# Altium schematic sheet pw_dist_v1.2_s1.SchDoc(pw_dist_v1.2_s1)
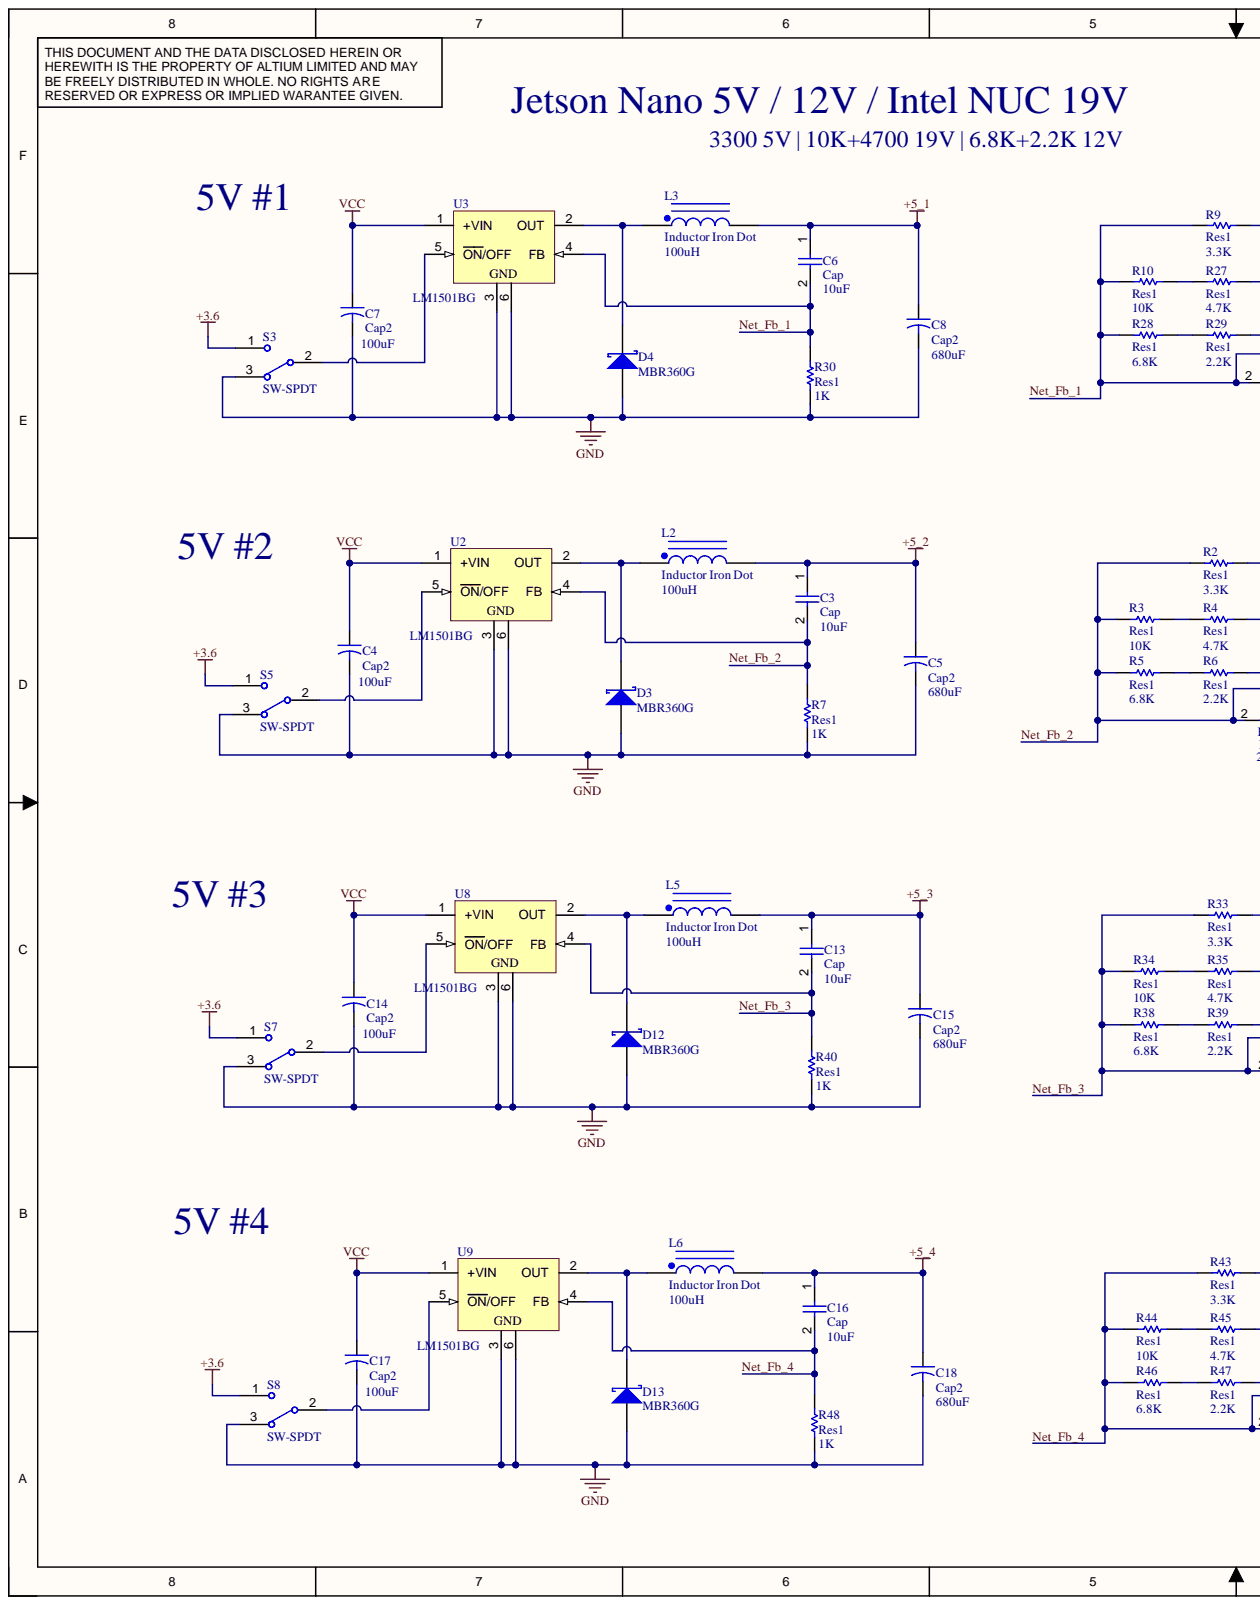
[diagram p0: pw_dist_v1.2_s1.SchDoc, left]
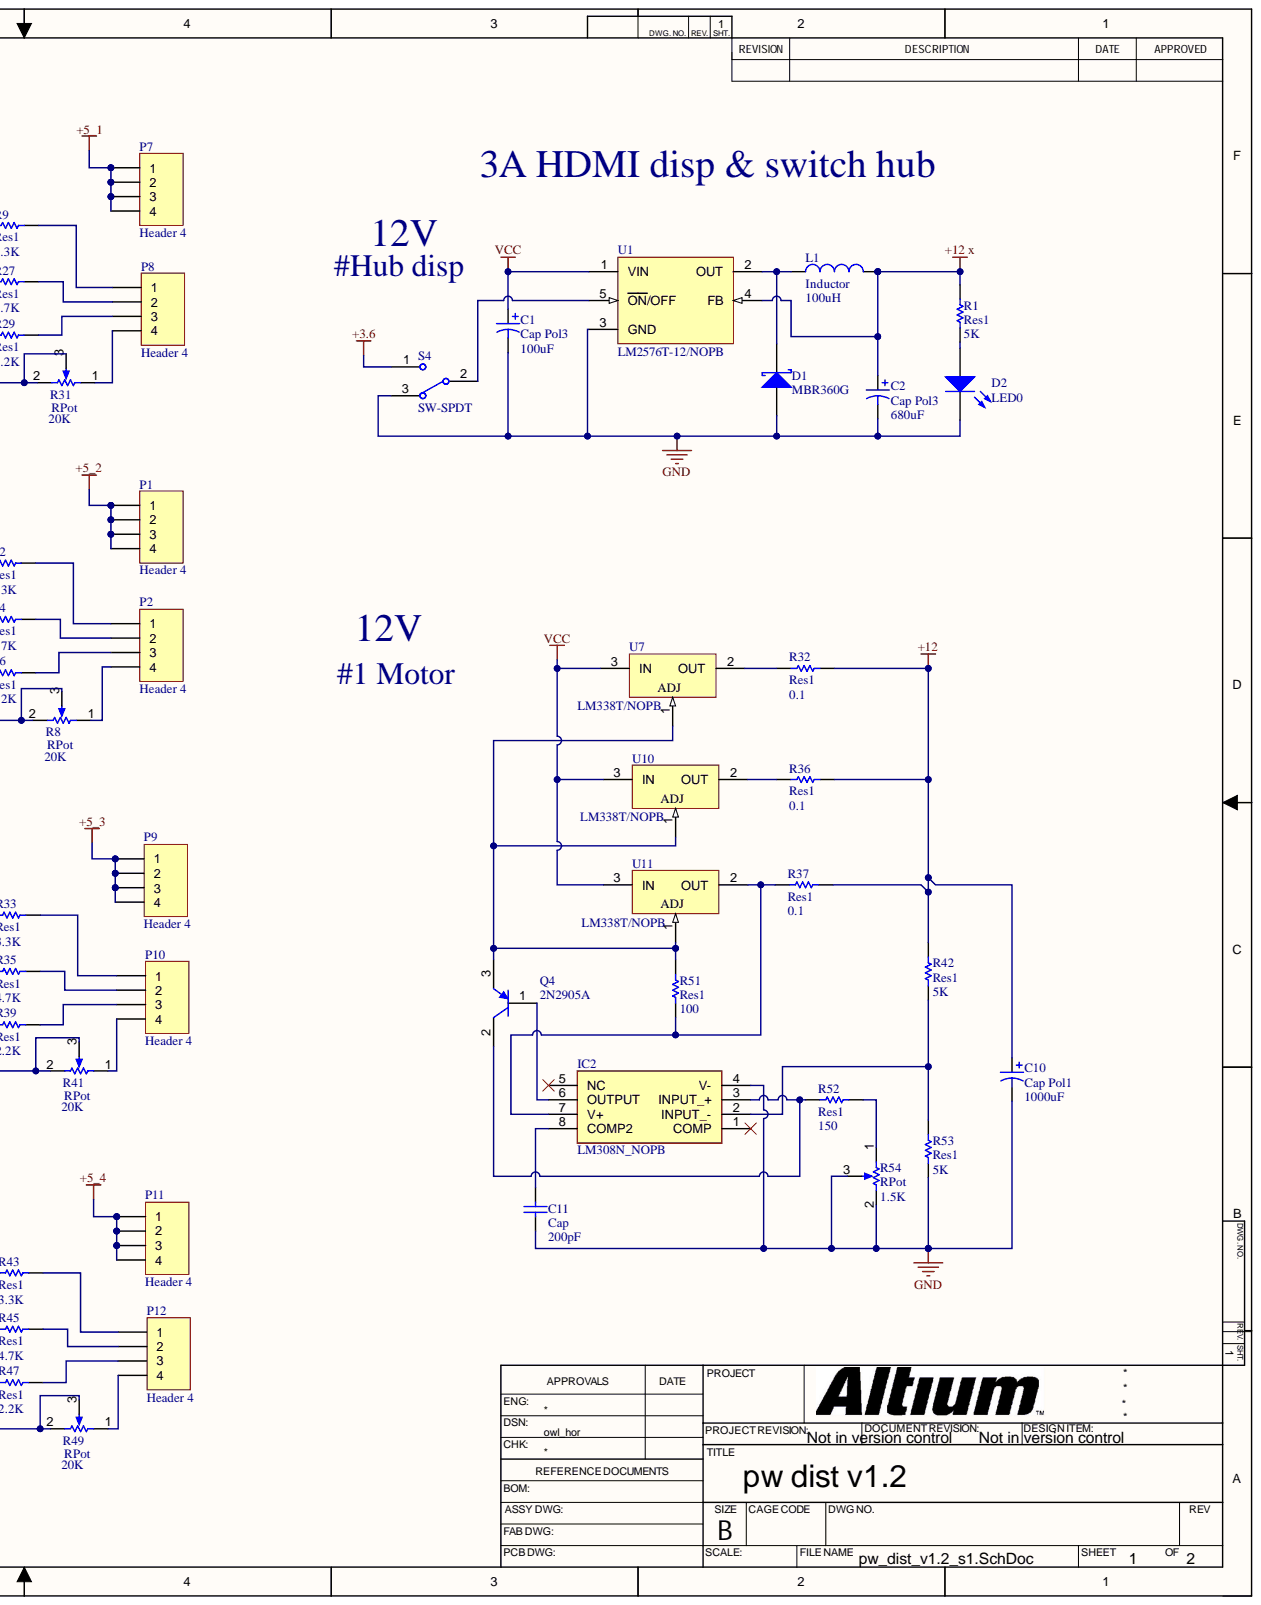
[diagram p1: pw_dist_v1.2_s1.SchDoc, right]

## Parts
C1  Cap Pol3  value 100uF  [CAPA_Q8_P3.5]
C2  Cap Pol3  value 680uF  [CAPA_Q10_P5.0]
C3  Cap  value 10uF  [Capa_Q5_p2.0]
C4  Cap2  value 100uF  [CAPA_Q8_P3.5]
C5  Cap2  value 680uF  [CAPA_Q10_P5.0]
C6  Cap  value 10uF  [Capa_Q5_p2.0]
C7  Cap2  value 100uF  [CAPA_Q8_P3.5]
C8  Cap2  value 680uF  [CAPA_Q10_P5.0]
C10  Cap Pol1  value 1000uF  [RB7.6-15]
C11  Cap  value 200pF  [RAD-0.1]
C13  Cap  value 10uF  [Capa_Q5_p2.0]
C14  Cap2  value 100uF  [CAPA_Q8_P3.5]
C15  Cap2  value 680uF  [CAPA_Q10_P5.0]
C16  Cap  value 10uF  [Capa_Q5_p2.0]
C17  Cap2  value 100uF  [CAPA_Q8_P3.5]
C18  Cap2  value 680uF  [CAPA_Q10_P5.0]
D1  MBR360G  [ONSC-AXIAL_LEAD-2-267-05_V]
D2  LED0  [TY-A1206PG1]
D3  MBR360G  [ONSC-AXIAL_LEAD-2-267-05_V]
D4  MBR360G  [ONSC-AXIAL_LEAD-2-267-05_V]
D12  MBR360G  [ONSC-AXIAL_LEAD-2-267-05_V]
D13  MBR360G  [ONSC-AXIAL_LEAD-2-267-05_V]
IC2  LM308N_NOPB  [DIP787W53P254L982H508Q8N]
L1  Inductor  value 100uH  [INDP9898X40N]
L2  Inductor Iron Dot  value 100uH  [IND_0803]
L3  Inductor Iron Dot  value 100uH  [IND_0803]
L5  Inductor Iron Dot  value 100uH  [IND_0803]
L6  Inductor Iron Dot  value 100uH  [IND_0803]
P1  Header 4  [HDR1X4]
P2  Header 4  [HDR1X4]
P7  Header 4  [HDR1X4]
P8  Header 4  [HDR1X4]
P9  Header 4  [HDR1X4]
P10  Header 4  [HDR1X4]
P11  Header 4  [HDR1X4]
P12  Header 4  [HDR1X4]
Q4  2N2905A  [STM-TO-39-3]
R1  Res1  value 5K  [Res_SMD_3mm]
R2  Res1  value 3.3K  [AXIAL-0.3]
R3  Res1  value 10K  [AXIAL-0.3]
R4  Res1  value 4.7K  [AXIAL-0.3]
R5  Res1  value 6.8K  [AXIAL-0.3]
R6  Res1  value 2.2K  [AXIAL-0.3]
R7  Res1  value 1K  [AXIAL-0.3]
R8  RPot  value 20K  [VR5]
R9  Res1  value 3.3K  [AXIAL-0.3]
R10  Res1  value 10K  [AXIAL-0.3]
R27  Res1  value 4.7K  [AXIAL-0.3]
R28  Res1  value 6.8K  [AXIAL-0.3]
R29  Res1  value 2.2K  [AXIAL-0.3]
R30  Res1  value 1K  [AXIAL-0.3]
R31  RPot  value 20K  [VR5]
R32  Res1  value 0.1  [Axial 0.65]
R33  Res1  value 3.3K  [AXIAL-0.3]
R34  Res1  value 10K  [AXIAL-0.3]
R35  Res1  value 4.7K  [AXIAL-0.3]
R36  Res1  value 0.1  [Axial 0.65]
R37  Res1  value 0.1  [Axial 0.65]
R38  Res1  value 6.8K  [AXIAL-0.3]
R39  Res1  value 2.2K  [AXIAL-0.3]
R40  Res1  value 1K  [AXIAL-0.3]
R41  RPot  value 20K  [VR5]
R42  Res1  value 5K  [Axial 0.65]
R43  Res1  value 3.3K  [AXIAL-0.3]
R44  Res1  value 10K  [AXIAL-0.3]
R45  Res1  value 4.7K  [AXIAL-0.3]
R46  Res1  value 6.8K  [AXIAL-0.3]
R47  Res1  value 2.2K  [AXIAL-0.3]
R48  Res1  value 1K  [AXIAL-0.3]
R49  RPot  value 20K  [VR5]
R51  Res1  value 100  [AXIAL-0.3]
R52  Res1  value 150  [AXIAL-0.3]
R53  Res1  value 5K  [Axial 0.65]
R54  RPot  value 1.5K  [VR5]
S3  SW-SPDT  [HDR1X3]
S4  SW-SPDT  [HDR1X3]
S5  SW-SPDT  [HDR1X3]
S7  SW-SPDT  [HDR1X3]
S8  SW-SPDT  [HDR1X3]
U1  LM2576T-12/NOPB  [KC0005A]
U2  LM1501BG  [KTT0005B_L]
U3  LM1501BG  [KTT0005B_L]
U7  LM338T/NOPB  [NDE0003A]
U8  LM1501BG  [KTT0005B_L]
U9  LM1501BG  [KTT0005B_L]
U10  LM338T/NOPB  [NDE0003A]
U11  LM338T/NOPB  [NDE0003A]

## Nets
+3.6: S3.1 S4.1 S5.1 S7.1 S8.1
+5_1: C6.1 C8.1 L3.2 P7.1 P7.2 P7.3 P7.4
+5_2: C3.1 C5.1 L2.2 P1.1 P1.2 P1.3 P1.4
+5_3: C13.1 C15.1 L5.2 P9.1 P9.2 P9.3 P9.4
+5_4: C16.1 C18.1 L6.2 P11.1 P11.2 P11.3 P11.4
+12: C10.1 R32.1 R36.1 R37.1 R42.2
+12 x: C2.1 L1.2 R1.2 U1.4(FB)
GND: C1.2 C2.2 C4.2 C5.2 C7.2 C8.2 C10.2 C11.1 C14.2 C15.2 C17.2 C18.2 D1.1 D2.2 D3.1 D4.1 D12.1 D13.1 IC2.4(V-) R7.1 R30.1 R40.1 R48.1 R53.1 R54.2 R54.3 S3.3 S4.3 S5.3 S7.3 S8.3 U1.3(GND) U2.3 U2.6 U3.3 U3.6 U8.3 U8.6 U9.3 U9.6
VCC: C1.1 C4.1 C7.1 C14.1 C17.1 U1.1(VIN) U2.1(+VIN) U3.1(+VIN) U7.3 U8.1(+VIN) U9.1(+VIN) U10.3 U11.3
Net_Fb_1: C6.2 R9.1 R10.1 R28.1 R30.2 R31.2 R31.3 U3.4(FB)
Net_Fb_2: C3.2 R2.1 R3.1 R5.1 R7.2 R8.2 R8.3 U2.4(FB)
Net_Fb_3: C13.2 R33.1 R34.1 R38.1 R40.2 R41.2 R41.3 U8.4(FB)
Net_Fb_4: C16.2 R43.1 R44.1 R46.1 R48.2 R49.2 R49.3 U9.4(FB)
NetC11_2: C11.2 IC2.8(COMP2)
NetD1_2: D1.2 L1.1 U1.2(OUT)
NetD2_1: D2.1 R1.1
NetD3_2: D3.2 L2.1 U2.2(OUT)
NetD4_2: D4.2 L3.1 U3.2(OUT)
NetD12_2: D12.2 L5.1 U8.2(OUT)
NetD13_2: D13.2 L6.1 U9.2(OUT)
NetIC2_2: IC2.2(INPUT_-) R42.1 R53.2
NetIC2_3: IC2.3(INPUT_+) Q4.2 R52.2
NetIC2_6: IC2.6(OUTPUT) Q4.1
NetIC2_7: IC2.7(V+) R37.2 R51.1 U11.2
NetP2_1: P2.1 R2.2
NetP2_2: P2.2 R4.2
NetP2_3: P2.3 R6.2
NetP2_4: P2.4 R8.1
NetP8_1: P8.1 R9.2
NetP8_2: P8.2 R27.2
NetP8_3: P8.3 R29.2
NetP8_4: P8.4 R31.1
NetP10_1: P10.1 R33.2
NetP10_2: P10.2 R35.2
NetP10_3: P10.3 R39.2
NetP10_4: P10.4 R41.1
NetP12_1: P12.1 R43.2
NetP12_2: P12.2 R45.2
NetP12_3: P12.3 R47.2
NetP12_4: P12.4 R49.1
NetQ4_3: Q4.3 R51.2 U7.1 U10.1 U11.1
NetR3_2: R3.2 R4.1
NetR5_2: R5.2 R6.1
NetR10_2: R10.2 R27.1
NetR28_2: R28.2 R29.1
NetR32_2: R32.2 U7.2
NetR34_2: R34.2 R35.1
NetR36_2: R36.2 U10.2
NetR38_2: R38.2 R39.1
NetR44_2: R44.2 R45.1
NetR46_2: R46.2 R47.1
NetR52_1: R52.1 R54.1
NetS3_2: S3.2 U3.5(ON/OFF)
NetS4_2: S4.2 U1.5(ON/OFF)
NetS5_2: S5.2 U2.5(ON/OFF)
NetS7_2: S7.2 U8.5(ON/OFF)
NetS8_2: S8.2 U9.5(ON/OFF)

Not connected: IC2 1(COMP) 5(NC)

## Library data of the parts
C1 C2 = Cap Pol3: Polarized Capacitor (Surface Mount); Component Kind: Standard; LatestRevisionDate: 29-May-2009; LatestRevisionNote: IPC-7351 Footprint Added.; Library Name: Miscellaneous Devices.IntLib; PackageDescription: Chip Capacitor; PackageReference: C0805; Published: 8-Jun-2000; Signal Integrity: Cap Pol; Simulation: CAP; Publisher: Altium Limited
C3 C6 C11 C13 C16 = Cap: Capacitor; Component Kind: Standard; LatestRevisionDate: 17-Jul-2002; LatestRevisionNote: Re-released for DXP Platform.; Library Name: Miscellaneous Devices.IntLib; PackageDescription: Radial Cap, Thru-Hole; 2 Leads; 0.3 in Pin Spacing; PackageReference: RAD-0.3; Published: 8-Jun-2000; Signal Integrity: Cap; Simulation: CAP; Publisher: Altium Limited
C4 C5 C7 C8 C14 C15 C17 C18 = Cap2: Capacitor; Component Kind: Standard; Library Name: Miscellaneous Devices.IntLib; PackageDescription: Capacitor; 2 Leads; PackageReference: CAPR5-4X5; Published: 23-Sep-2002; Signal Integrity: Cap; Simulation: CAP; Publisher: Altium Limited
C10 = Cap Pol1: Polarized Capacitor (Radial); Component Kind: Standard; LatestRevisionDate: 17-Jul-2002; LatestRevisionNote: Re-released for DXP Platform.; Library Name: Miscellaneous Devices.IntLib; PackageDescription: Polarized Capacitor (Radial); 2 Leads; PackageReference: RB7.6-15; Published: 8-Jun-2000; Signal Integrity: Cap Pol; Simulation: CAP; Publisher: Altium Limited
D1 D3 D4 D12 D13 = CMP-1055-00015-2: Axial Lead Rectifier, 60 V, 3 A, -65 to 150 degC, 2-Pin DO-201AD, RoHS, Bag; Case\Package: 0603; Colour: Green; Forward Current: 20 mA; Forward Voltage: 2.2 V; Lens Style: Diffused; Luminous Intensity: 15 mcd; Nominal Wavelength: 572 nm; Power Rating: 52 mW; Viewing Angle: 170 degrees; Case Code (Imperial): 0603; Case Code (Metric): 1608; Component Kind: Standard; ComponentLink1Description: Manufacturer URL; ComponentLink1URL: http://www.onsemi.com/; ComponentLink2Description: Datasheet; ComponentLink2URL: http://www.onsemi.cn/pub_link/Collateral/MBR350-D.PDF; ComponentLink3Description: Package Specification; ComponentLink3URL: http://www.onsemi.com/pub_link/Collateral/267-05.PDF; DatasheetVersion: Rev. 6, 06/2006; Height: 0.8 mm; Illumination Colour: Green; Length: 1.6 mm; Library Name: Altium Content Vault; Max Operating Temperature: 85 degC; Min Operating Temperature: -40 degC; Mount: Surface Mount; Nominal Vf: 2.2 V; Package Quantity: 4000; PackageDescription: 2-Pin Axial Lead, Dia 5.05 mm, Body Length 8.4 mm; PackageReference: AXIAL_LEAD-2-267-05; Packaging: Tape and Reel; Pins: 2; Radiation Hardening: No; REACH SVHC: No SVHC; RoHSCompliant: true; Width: 0.8 mm
D2 = LED0: Typical INFRARED GaAs LED; Component Kind: Standard; LatestRevisionDate: 08-Jul-2005; LatestRevisionNote: Mech Layer 1 removed.; Library Name: Miscellaneous Devices.IntLib; PackageDescription: LED; 2 Leads; PackageReference: LED-0; Published: 8-Jun-2000; Simulation: LED0; Publisher: Altium Limited
IC2 = LM308N_NOPB: Integrated Circuit; Manufacturer_Name: Texas Instruments; Manufacturer_Part_Number: LM308N/NOPB; Component Kind: Standard; Datasheet Link: http://www.mit.edu/~6.301/LM108.pdf; Height: 5.08mm; Mouser Part Number: N/A; Mouser Price/Stock: https://www.mouser.com/Search/Refine.aspx?Keyword=N%2FA
L1 = Inductor: Code_IEC: 1005; Code_JEITA: 0402; Component Kind: Standard; Description: Inductor; LatestRevisionDate: 29-May-2009; LatestRevisionNote: IPC-7351 Footprint Added.; Library Name: Miscellaneous Devices.IntLib; PackageDescription: Chip Inductor; PackageReference: 0402-A; Published: 8-Jun-2000; Signal Integrity: Inductor; Simulation: INDUCTOR; Publisher: Altium Limited
L2 L3 L5 L6 = Inductor Iron Dot: Magnetic-Core Inductor with Winding Polarity Marking; Component Kind: Standard; LatestRevisionDate: 17-Jul-2002; LatestRevisionNote: Re-released for DXP Platform.; Library Name: Miscellaneous Devices.IntLib; PackageDescription: Diode; 2 Leads; PackageReference: DIODE_SMC; Published: 24-Mar-1999; Signal Integrity: Inductor Iron Dot; Publisher: Altium Limited
P1 P2 P7-P12 = Header 4: Header, 4-Pin; Component Kind: Standard; LatestRevisionDate: 17-Jul-2002; LatestRevisionNote: Re-released for DXP Platform.; Library Name: Miscellaneous Connectors.IntLib; Signal Integrity: Connector; Publisher: Altium Limited
Q4 = CMP-2000-05560-1: Small Singal PNP Transistor, 200 MHz, -65 to 175 degC, 3-Pin TO-39, RoHS; Manufacturer: STMicroelectronics; Collector Emitter Voltage V(br)ceo: -60V; DC Collector Current: -600mA; DC Current Gain hFE: 50hFE; No. of Pins: 3Pins; Power Dissipation Pd: 600mW; Transition Frequency ft: 200MHz; Automotive Qualification Standard: -; Component Kind: Standard; Datasheet URL: https://datasheet.ciiva.com/15139/getdatasheetpartid-82818-15139087.pdf; Datasheet Version: 02/2003; Library Name: Altium Content Vault; Manufacturer URL: http://www.st.com/; Mounting Technology: ThroughHole; Operating Temperature Max: 175°C; Package Description: 3-Pin Transistor Outlines Package, Dia 9.4 mm, Height 6.6 mm; Package Reference: TO-39-3; Product Range: -; Transistor Case Style: TO-39; Transistor Polarity: PNP
R1 R5-R7 R28-R30 R32 R36-R40 R42 R46-R48 R51-R53 = Res1: Resistor; Component Kind: Standard; LatestRevisionDate: 17-Jul-2002; LatestRevisionNote: Re-released for DXP Platform.; Library Name: Miscellaneous Devices.IntLib; PackageDescription: Axial Device, Thru-Hole; 2 Leads; 0.3 in Pin Spacing; PackageReference: AXIAL-0.3; Published: 8-Jun-2000; Signal Integrity: Res; Simulation: RESISTOR; Publisher: Altium Limited
R2 R9 R33 R43 = Res1: Resistor; Value: 3.3K; Component Kind: Standard; LatestRevisionDate: 17-Jul-2002; LatestRevisionNote: Re-released for DXP Platform.; Library Name: Miscellaneous Devices.IntLib; PackageDescription: Axial Device, Thru-Hole; 2 Leads; 0.3 in Pin Spacing; PackageReference: AXIAL-0.3; Published: 8-Jun-2000; Signal Integrity: Res; Simulation: RESISTOR; Publisher: Altium Limited
R3 R10 R34 R44 = Res1: Resistor; Value: 10K; Component Kind: Standard; LatestRevisionDate: 17-Jul-2002; LatestRevisionNote: Re-released for DXP Platform.; Library Name: Miscellaneous Devices.IntLib; PackageDescription: Axial Device, Thru-Hole; 2 Leads; 0.3 in Pin Spacing; PackageReference: AXIAL-0.3; Published: 8-Jun-2000; Signal Integrity: Res; Simulation: RESISTOR; Publisher: Altium Limited
R4 R27 R35 R45 = Res1: Resistor; Value: 4.7K; Component Kind: Standard; LatestRevisionDate: 17-Jul-2002; LatestRevisionNote: Re-released for DXP Platform.; Library Name: Miscellaneous Devices.IntLib; PackageDescription: Axial Device, Thru-Hole; 2 Leads; 0.3 in Pin Spacing; PackageReference: AXIAL-0.3; Published: 8-Jun-2000; Signal Integrity: Res; Simulation: RESISTOR; Publisher: Altium Limited
R8 R31 R41 R49 R54 = RPot: Potentiometer; Set Position: 0.5; Sim Note: Enter the Resistance value and the set point into parameter fields.; Component Kind: Standard; LatestRevisionDate: 17-Jul-2002; LatestRevisionNote: Re-released for DXP Platform.; Library Name: Miscellaneous Devices.IntLib; PackageDescription: Variable Resistor, Thru-Hole; 3 Leads; PackageReference: VR5; Published: 8-Jun-2000; Simulation: POT; Publisher: Altium Limited
S3-S5 S7 S8 = SW-SPDT: SPDT Subminiature Toggle Switch, Right Angle Mounting, Vertical Actuation; Component Kind: Standard; ComponentLink1Description: Manufacturer Link; ComponentLink1URL: http://www.apem.com; DatasheetVersion: 05-Dec-2000; LatestRevisionDate: 17-Dec-2003; LatestRevisionNote: Schematic revised, PCB Footprint replaced.; Library Name: Miscellaneous Devices.IntLib; PackageDescription: Switch; 4 Leads; PackageReference: TL36WW15050; Published: 24-Mar-1999; Publisher: Altium Limited
U1 = CMP-0069-01985-3: SIMPLE SWITCHER® 3A Step-Down Voltage Regulator, 5-pin TO-220, Pb-Free; Manufacturer: Texas Instruments; PackageTopMarking: NSUZXYTTE#*LM2576T*-12 P+; PowerWise: N; Code_JEDEC: TO-220-AB; Component Kind: Standard; ComponentLink1Description: Datasheet URL; ComponentLink1URL: http://www.ti.com/general/docs/lit/getliterature.tsp?genericPartNumber=LM2576&fileType=pdf; CompType: Switcher; Library Name: Altium Content Vault; PackageDescription: TO-220, Molded, 5 Lead, Straight; PackageReference: KC0005A; PinCount: 5; RoHS: Y
U2 U3 U8 U9 = CMP-2000-06545-1: Simple Switcher(R) Power Converter 150 kHz Step-Down Voltage Regulator, 3 A, 4.5 to 40 V, -40 to 125 degC, 5-Pin TO-263 (KTT), Green (RoHS & no Sb/Br), Tape and Reel; Manufacturer: Texas Instruments; PartNumber: LM2596; No. of Outputs: 1; No. of Pins: 5; Output Current: 3A; Output Voltage: 37V; Package Version: 4200577-4/G, 01/2013; Primary Input Voltage: 40V; SVHC: NoSVHC(19-Dec-2012); Component Kind: Standard; Datasheet URL: http://www.ti.com/lit/ds/symlink/lm2596.pdf; Datasheet Version: Rev. C, 04/2013; Library Name: Altium Content Vault; Manufacturer URL: http://www.ti.com/; Mounting Technology: SurfaceMount; MSL: MSL3-168hours; Number of Outputs: 1; Operating Temperature Max: 125°C; Operating Temperature Min: -40°C; Operating Temperature Range: -40°Cto+125°C; Package Description: 5-Pin Plastic Flange Mount, Body 10.16 x 8.925 mm, Pitch 1.7 mm; Package Reference: KTT5; Package URL: http://www.ti.com/litv/pdf/mpsf007e; Voltage Regulator Case Style: TO-263
U7 U10 U11 = CMP-0062-02474-3: 5 Amp Adjustable Regulator, 3-pin TO-220, Pb-Free; Manufacturer: Texas Instruments; CompType: LDO IC; PackageTopMarking: NSUZXYTTE#*LM338T P+; PowerWise: N; Component Kind: Standard; ComponentLink1Description: Datasheet URL; ComponentLink1URL: http://www.ti.com/general/docs/lit/getliterature.tsp?genericPartNumber=LM338&fileType=pdf; Library Name: Altium Content Vault; PackageDescription: TO-220, Molded, 3 Lead, Straight; PackageReference: NDE0003A; PinCount: 3; RoHS: Y
